# Altium schematic sheet [07] - 5V VOLTAGE REGULATOR.SchDoc("[07] - 5V VOLTAGE REGULATOR")
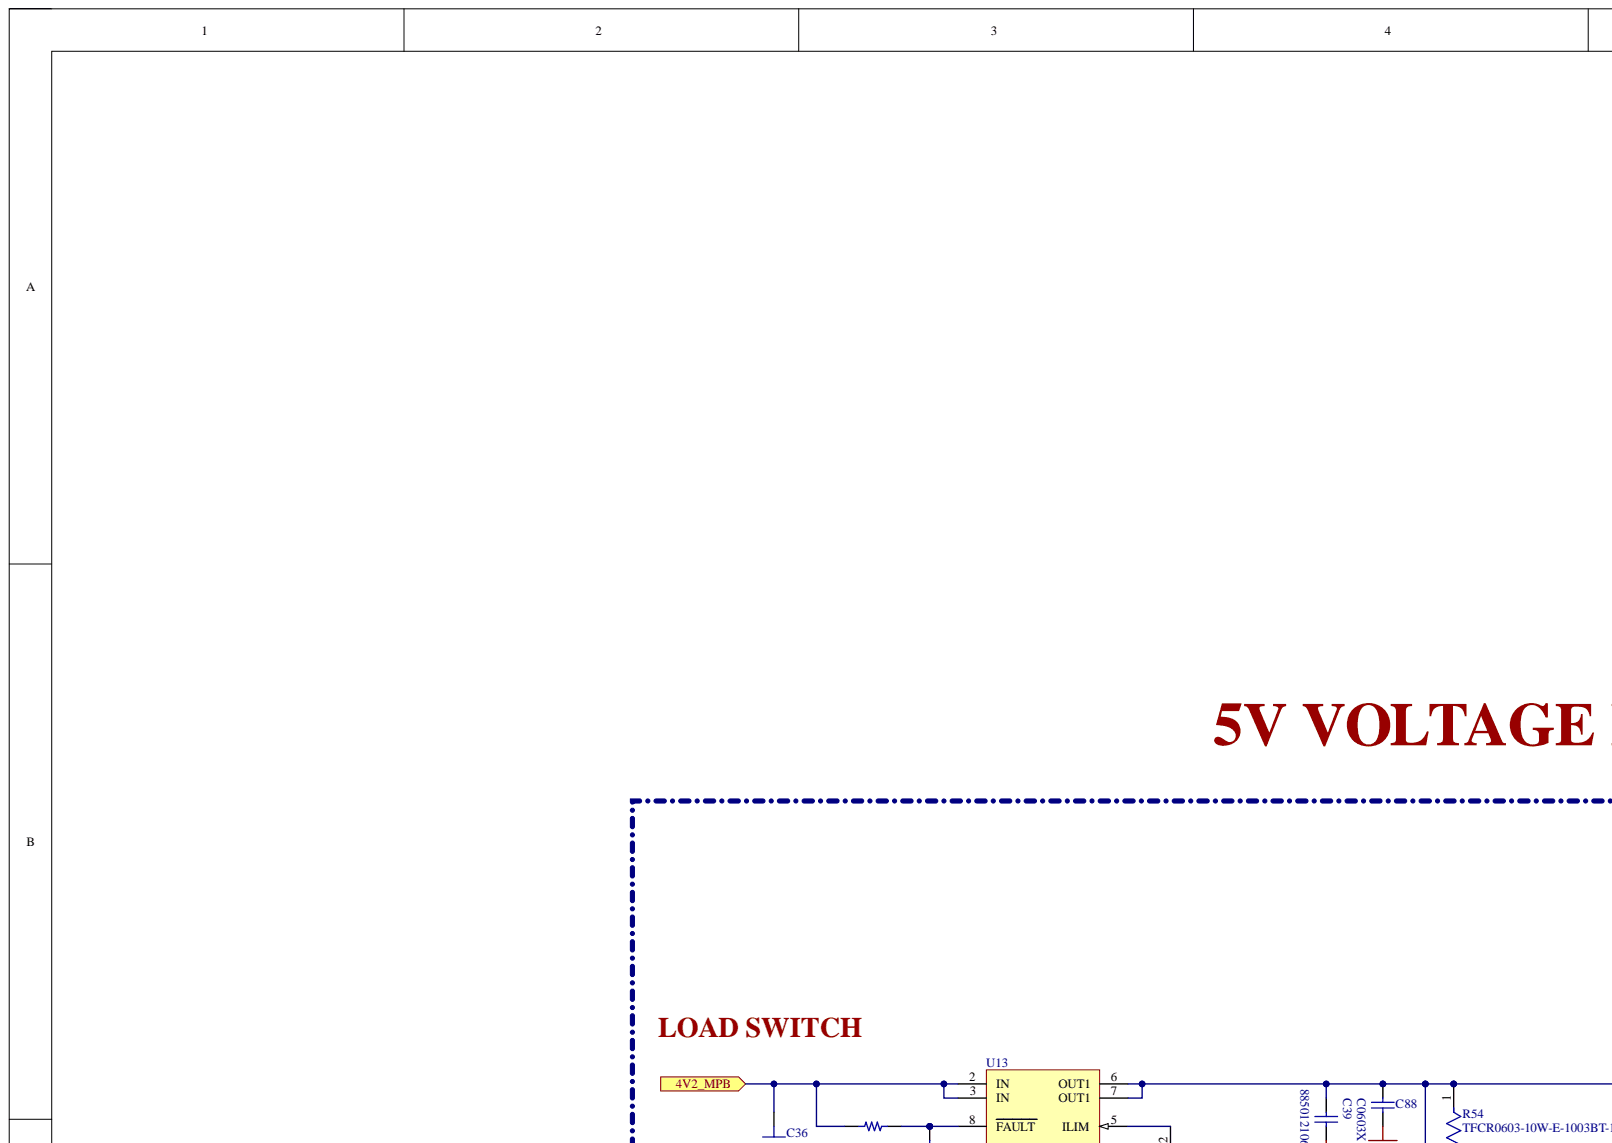
[diagram p0: [07] - 5V VOLTAGE REGULATOR.SchDoc, top left]
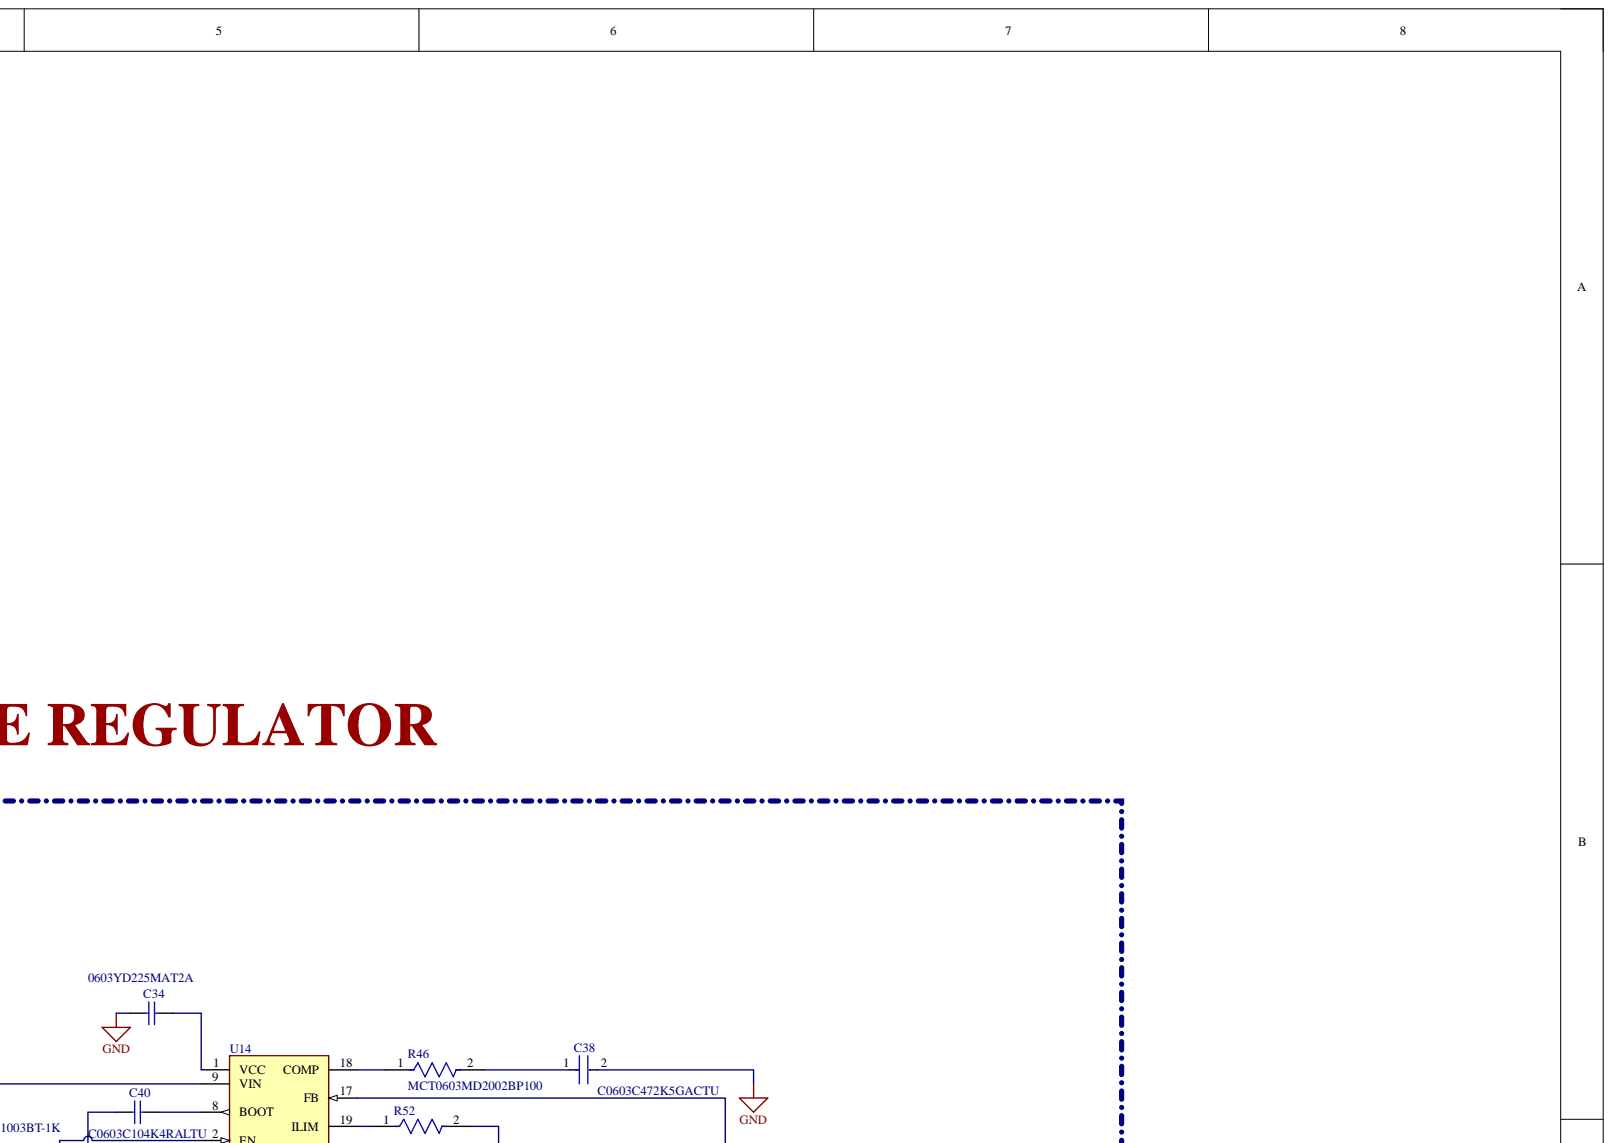
[diagram p1: [07] - 5V VOLTAGE REGULATOR.SchDoc, top right]
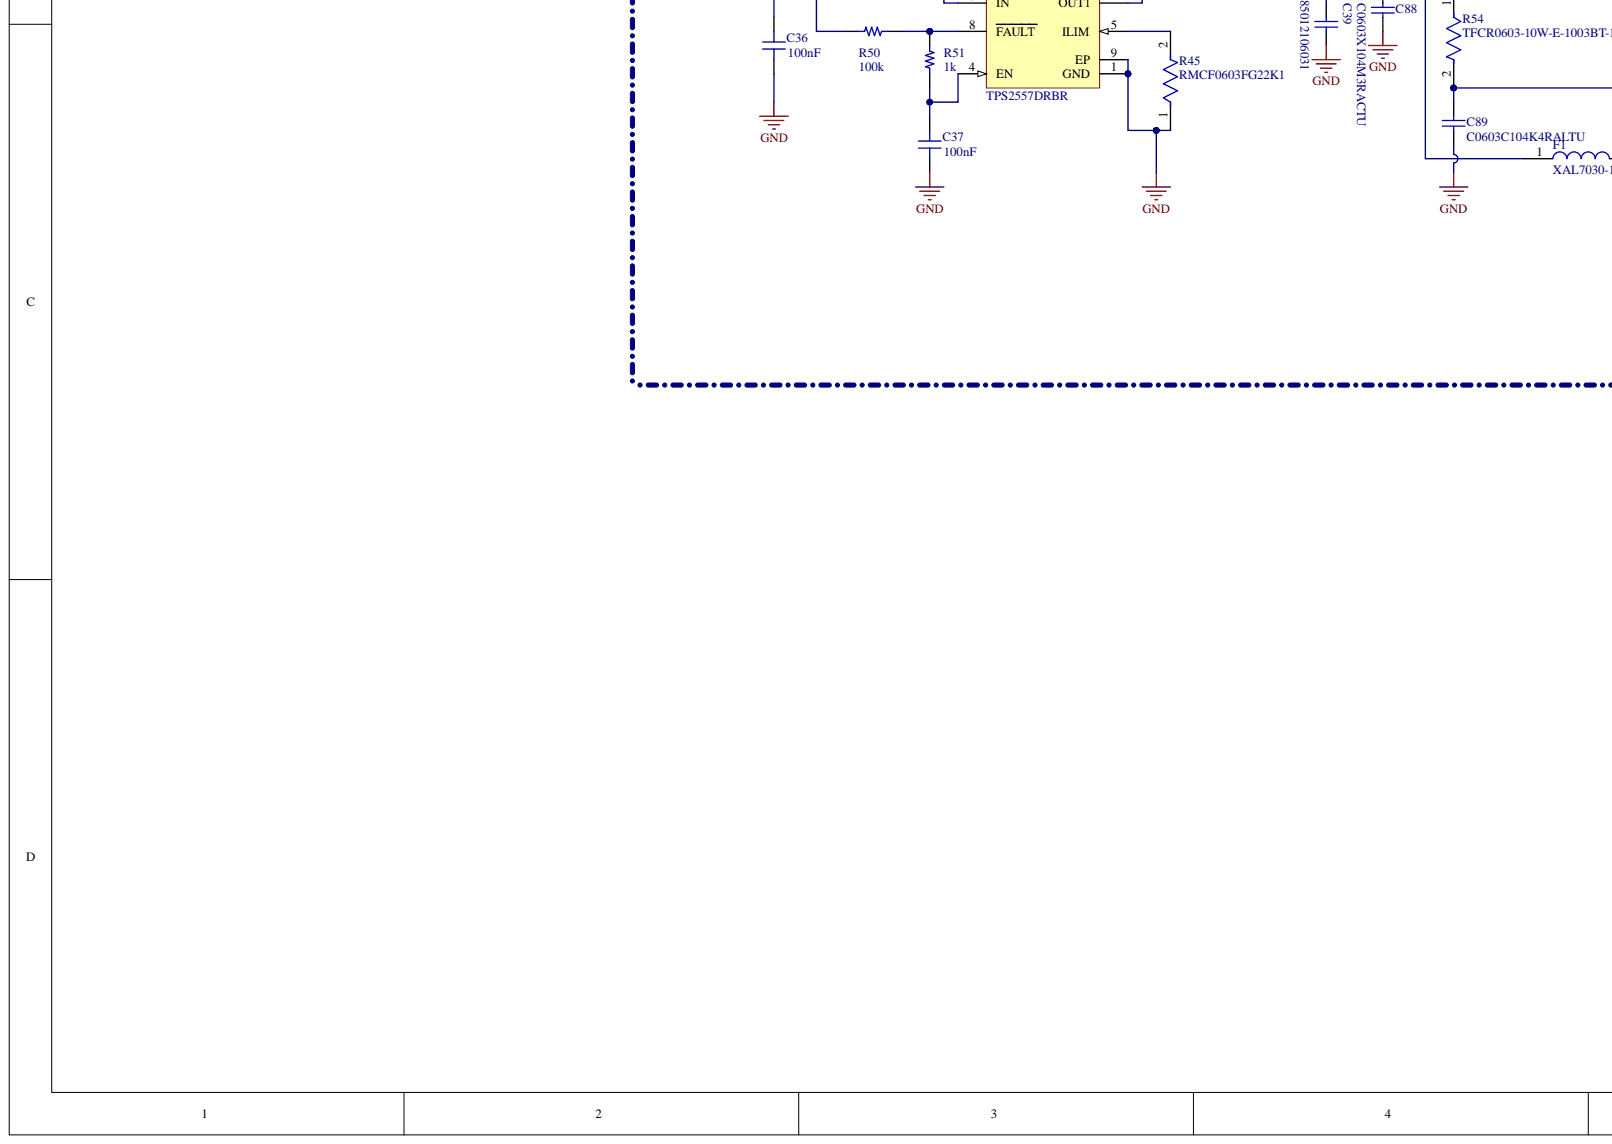
[diagram p2: [07] - 5V VOLTAGE REGULATOR.SchDoc, bottom left]
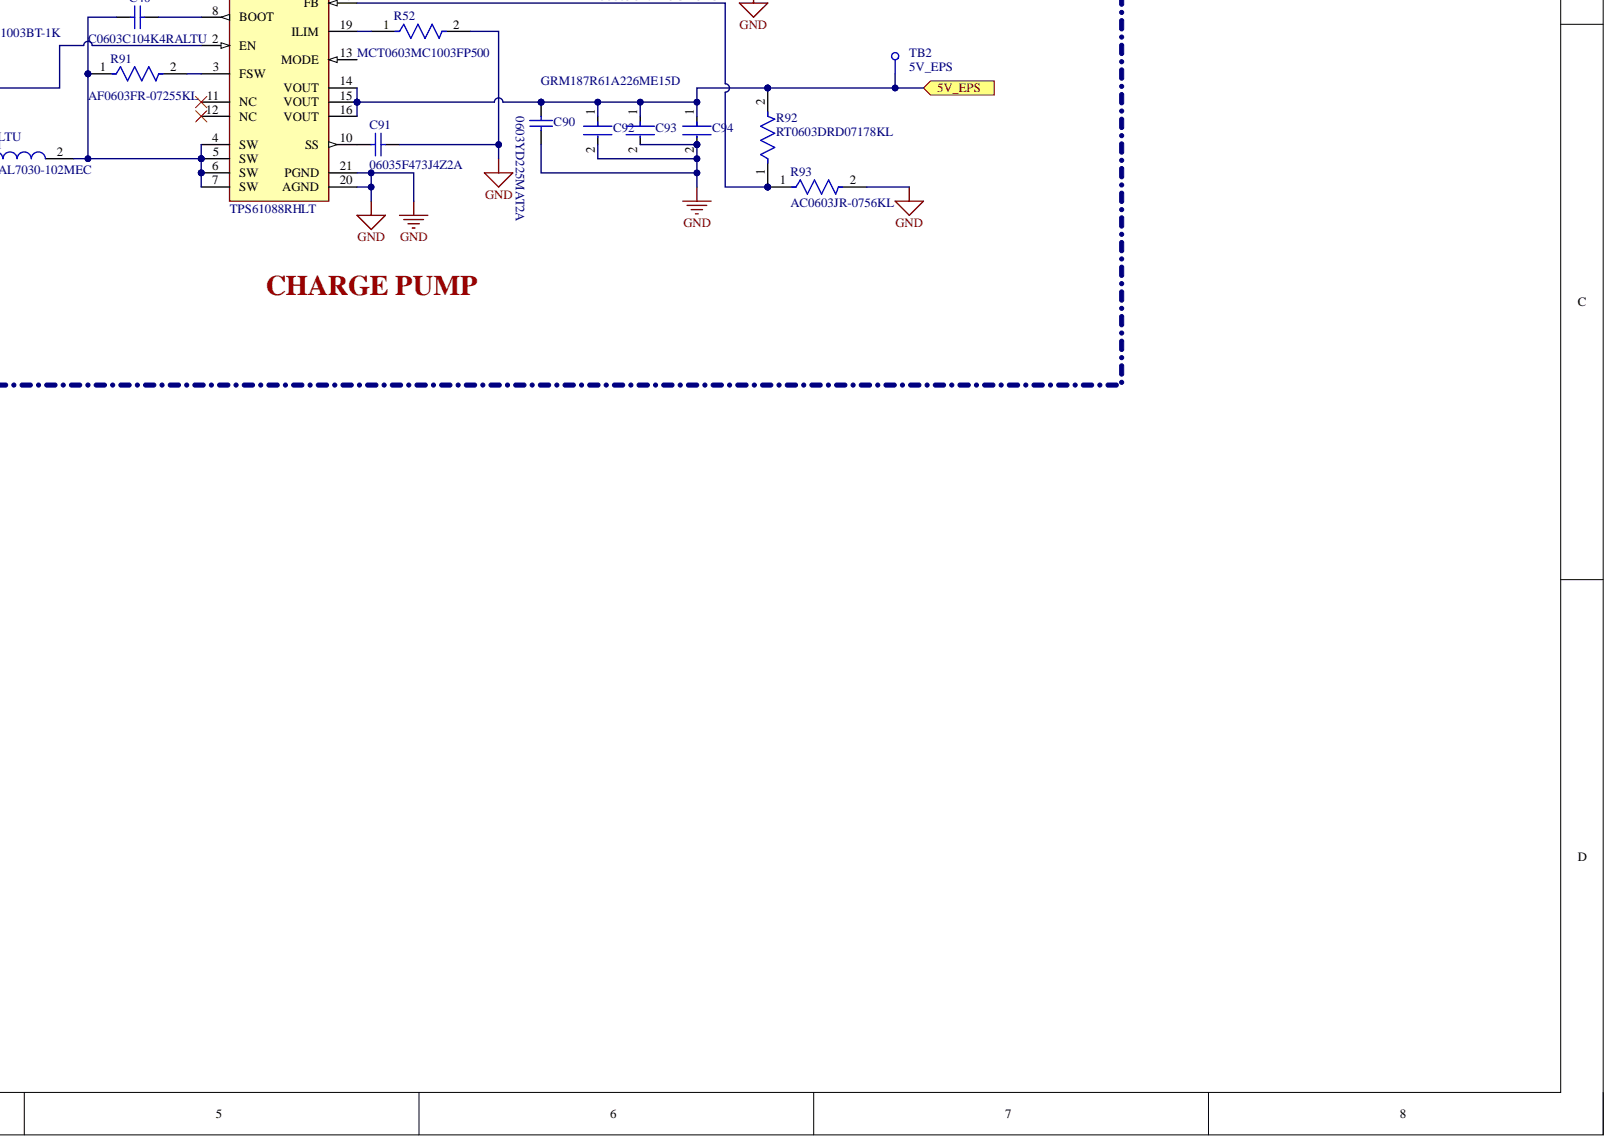
[diagram p3: [07] - 5V VOLTAGE REGULATOR.SchDoc, bottom right]

## Parts
C34  0603YD225MAT2A  [CAPC1608X90X35NL15T15]
C36  Capacitance: 100nF  [CAP 0603/1608]  (hidden comment: C0603C104K8RACTU)
C37  Capacitance: 100nF  [CAP 0603/1608]  (hidden comment: C0603C104K8RACTU)
C38  C0603C472K5GACTU  [FP-C0603C-CF-MFG]
C39  885012106031  [WCAP-CSGP_0603_L1.6W0.8H0.8_Reflow]
C40  C0603C104K4RALTU  [CAPC1608X87X35ML15T15]
C88  C0603X104M3RACTU  [CAPC1608X87X35LL15T15]
C89  C0603C104K4RALTU  [CAPC1608X87X35ML15T15]
C90  0603YD225MAT2A  [CAPC1608X90X35NL15T15]
C91  06035F473J4Z2A  [CAPC1608X90X35NL15T15]
C92  GRM187R61A226ME15D  [FP-GRM187-0_2-IPC_C]
C93  GRM187R61A226ME15D  [FP-GRM187-0_2-IPC_C]
C94  GRM187R61A226ME15D  [FP-GRM187-0_2-IPC_C]
F1  XAL7030-102MEC  [XAL7030102MEC]
R45  RMCF0603FG22K1  [FP-RMCF0603-MFG]
R46  MCT0603MD2002BP100  [FP-MCT0603-IPC_A]
R50  Resistance: 100k  [RES 0603/1608]  (hidden comment: CR0603-JW-104ELF)
R51  Resistance: 1k  [RES 0603/1608]  (hidden comment: ESR03EZPJ102)
R52  MCT0603MC1003FP500  [FP-MCT0603-IPC_C]
R54  TFCR0603-10W-E-1003BT-1K  [FP-TFCR0603-IPC_C]
R91  AF0603FR-07255KL  [FP-AF0603-IPC_B]
R92  RT0603DRD07178KL  [FP-RT0603-MFG]
R93  AC0603JR-0756KL  [FP-AC0603-MFG]
TB2  5V_EPS  [Testpoint]
U13  TPS2557DRBR  [DRB0008B_V]
U14  TPS61088RHLT  [FP-RHL0020A-MFG]

## Ports
4V2_MPB, 5V_EPS

## Nets
GND: C34.1 C36.1 C37.1 C38.2 C39.2 C88.1 C89.1 C90.1 C91.2 C92.2 C93.2 C94.2 R45.1 R52.2 R93.2 U13.1(GND) U13.9(EP) U14.20(AGND) U14.21(PGND)
4V2_MPB: C36.2 R50.1 U13.2(IN) U13.3(IN) ; port 4V2_MPB
5V_EPS: C90.2 C92.1 C93.1 C94.1 R92.2 TB2.1 U14.14(VOUT) U14.15(VOUT) U14.16(VOUT) ; port 5V_EPS
NetC34_2: C34.2 U14.1(VCC)
NetC37_2: C37.2 R51.1 U13.4(EN)
NetC38_1: C38.1 R46.2
NetC39_1: C39.1 C88.2 F1.1 R54.1 U13.6(OUT1) U13.7(OUT1) U14.9(VIN)
NetC40_1: C40.1 F1.2 R91.1 U14.4(SW) U14.5(SW) U14.6(SW) U14.7(SW)
NetC40_2: C40.2 U14.8(BOOT)
NetC89_2: C89.2 R54.2 U14.2(EN)
NetC91_1: C91.1 U14.10(SS)
NetR45_2: R45.2 U13.5(ILIM)
NetR46_1: R46.1 U14.18(COMP)
NetR50_2: R50.2 R51.2 U13.8(FAULT)
NetR52_1: R52.1 U14.19(ILIM)
NetR91_2: R91.2 U14.3(FSW)
NetR92_1: R92.1 R93.1 U14.17(FB)

Not connected: U14 11(NC) 12(NC) 13(MODE)

## Library data of the parts
C34 C90 = CMP-2006-04537-1: Manufacturer: AVX Interconnect / Elco; Component Kind: Standard; Library Name: Altium Content Vault; Part Number: 0603YD225MAT2A
C36 C37 = C0603C104K8RACTU: CAP CER 0.1UF 10V X7R 0603; Manufacturer: KEMET; Dielectric Material: Ceramic; Dissipation Factor: 5%; Tolerance: 10%; Case Code (Imperial): 0603; Case Code (Metric): 1608; Case/Package: 0603; Component Kind: Standard; ComponentLink1Description: http://www.farnell.com/datasheets/2315110.pdf?src-supplier=Farnell; ComponentLink1URL: http://www.farnell.com/datasheets/2315110.pdf?src-supplier=Farnell; ComponentLink2Description: http://www.kemet.com/kemet/web/homepage/kechome.nsf/vapubfiles/KEM_C1002_X7R_SMD.pdf/$file/KEM_C1002_X7R_SMD.pdf?src-supplier=Element14; ComponentLink2URL: http://www.kemet.com/kemet/web/homepage/kechome.nsf/vapubfiles/KEM_C1002_X7R_SMD.pdf/$file/KEM_C1002_X7R_SMD.pdf?src-supplier=Element14; ComponentLink3Description: https://www.verical.com/server-webapp/html/getDatasheet?partID=91529&src-supplier=Verical; ComponentLink3URL: https://www.verical.com/server-webapp/html/getDatasheet?partID=91529&src-supplier=Verical; ComponentLink4Description: http://www.mouser.com/ds/2/212/KEM_C1002_X7R_SMD-1102033.pdf?src-supplier=Mouser; ComponentLink4URL: http://www.mouser.com/ds/2/212/KEM_C1002_X7R_SMD-1102033.pdf?src-supplier=Mouser; ComponentLink5Description: http://www.alliedelec.com/m/d/9bfe7773041ffe9d406e68bc7b377fdd.pdf?src-supplier=Allied+Electronics; ComponentLink5URL: http://www.alliedelec.com/m/d/9bfe7773041ffe9d406e68bc7b377fdd.pdf?src-supplier=Allied+Electronics; ComponentLink6Description: http://www.farnell.com/datasheets/1851736.pdf?src-supplier=Element14; ComponentLink6URL: http://www.farnell.com/datasheets/1851736.pdf?src-supplier=Element14; Contact Plating: Tin,Matte; Depth: 0.8mm; Dielectric: X7R; Height: 0.8mm; Lead Pitch: 0.7mm; Length: 1.6mm; Manufacturer Part Number: C0603C104K8RACTU; Max Operating Temperature: 125°C; Min Operating Temperature: -55°C; Mount: Surface Mount,PCB; Number of Pins: 2; Package Quantity: 1; Packaging: Tape and Reel; Pricing 1: 1=0.15, 10=0.107, 100=0.0504, 500=0.03536, 1000=0.02927 (USD); Radiation Hardening: No; REACH SVHC: No SVHC; Stock 1: 778651; Supplier 1: Digi-Key; Supplier Part Number 1: 399-1095-1-ND; Termination: SMD/SMT; Thickness: 0.034inch; Voltage: 10V; Voltage Rating: 10V; Voltage Rating (DC): 10V; Width: 0.8mm; Library Name: UVG.IntLib
C38 = CMP-2006-03600-2: CAP CER 4700PF 50V 10% NP0 0603; Manufacturer: KEMET; Automotive Grade: Grade 1; Capacitance: 4,700 pF; Material: Ceramic; Temperature Characteristic: C0G; Temperature Coefficient: 30ppm/°C; Tolerance: 0.1; Value: 4,700 pF; Automotive: Yes; Category: Cap; Component Kind: Standard; ComponentLink2Description: Datasheet; ComponentLink2URL: https://api.kemet.com/component-edge/download/datasheet/C0603C100J5GACAUTO.pdf; Device Class L1: Passive Components; Device Class L2: Capacitors; Device Class L3: Ceramic Capacitors; Digikey Description: CAP CER 4700PF 50V 10% NP0 0603; Height: 0.87mm; Lead Free: Yes; Library Name: Altium Content Vault; Manufacturer Part Number: C0603C472K5GACTU; Mouser Description: Cap Ceramic 0.0047uF 50V C0G 10% SMD 0603 125°C Paper T/R; Octopart: https://octopart.com/c0603c472k5gactu-kemet-19050079; Package: C0603C-CF; RoHS: TRUE; Temperature: -55°C to +125°C; Temperature Range High: +125°C; Temperature Range Low: -55°C; Voltage Rating: 50 V
C39 = CMP-207839-000060-3: Cap, 10Uf, 25V, Mlcc, 0603 Rohs Compliant: Yes; Capacitance: 10µF; Insulation Resistance: 10MΩ; Component Kind: Standard; Height: 800µm; Length: 1.6mm; Library Name: Altium Content Vault; Max Operating Temperature: 85°C; Min Operating Temperature: -55°C; RoHS: Compliant; Voltage Rating: 25V; Width: 800µm
C40 C89 = CMP-2006-03059-1: Manufacturer: Kemet; Component Kind: Standard; Library Name: Altium Content Vault; Part Number: C0603C104K4RALTU
C88 = CMP-2006-03301-1: Manufacturer: Kemet; Component Kind: Standard; Library Name: Altium Content Vault; Part Number: C0603X104M3RACTU
C91 = CMP-2006-02143-1: Manufacturer: AVX Interconnect / Elco; Component Kind: Standard; Library Name: Altium Content Vault; Part Number: 06035F473J4Z2A
C92-C94 = CMP-06035-049226-1: Chip Multilayer Ceramic Capacitors for General Purpose, 0603, 22uF, X5R, 15%, 20%, 10V; Capacitance: 22uF; Case Code (Imperial): 0603; Case Code (Metric): 1608; Case/Package: 0603; Component Kind: Standard; Dielectric: X5R; Length: 0.063inch; Library Name: Altium Content Vault; Max Operating Temperature: 85°C; Min Operating Temperature: -55°C; Mount: Surface Mount; Packaging: Tape and Reel; Thickness: 0.031inch; Tolerance: 20%; Voltage Rating: 10V; Voltage Rating (DC): 10V; Width: 0.031inch
F1 = XAL7030-102MEC: Inductor; Manufacturer_Name: COILCRAFT; Component Kind: Standard; Datasheet Link: https://www.coilcraft.com/pdfs/xal7030.pdf; Height: 3.1mm; Manufacturer_Part_Number: XAL7030-102MEC; Mouser Part Number: 994-XAL7030-102MEC; Mouser Price/Stock: https://www.mouser.co.uk/ProductDetail/Coilcraft/XAL7030-102MEC?qs=zCSbvcPd3pYbk2HvDIgkpA%3D%3D
R45 = CMP-26527-003325-1: 22.1kΩ ±1% 0.1W 0603 Thick Film Chip Resistor AEC-Q200 compliant; Max Power Dissipation: 100mW; Resistance: 22.1kR; Temperature Coefficient: 100ppm/°C; Case/Package: 0603; Component Kind: Standard; Contact Plating: Tin; Features: Automotive AEC-Q200; Height: 0.022inch; Length: 0.061inch; Library Name: Altium Content Vault; Max Operating Temperature: 155°C; Min Operating Temperature: -55°C; Mount: Surface Mount; Number of Terminations: 2; Packaging: Tape and Reel; Radiation Hardening: No; Resistor Type: Thick Film; RoHS Compliant: Yes; Series: RMCF; Tolerance: 1%; Width: 0.031inch
R46 = CMP-02407-011165-1: RES SMD 100 OHM 1% 1/8W 0603; Max Power Dissipation: 125mW; Resistance: 20kR; Temperature Coefficient: 25ppm/°C; Tolerance: 0.1%; Voltage Rating: 75V; Case Code (Imperial): 0603; Case Code (Metric): 1608; Case/Package: 0603; Component Kind: Standard; Construction: Rectangular; Depth: 0.85mm; Features: Automotive AEC-Q200; Height: 0.022inch; Length: 1.55mm; Library Name: Altium Content Vault; Max Operating Temperature: 155°C; Military Standard: Not; Min Operating Temperature: -55°C; Number of Terminations: 2; Package Quantity: 1000; Packaging: Tape and Reel; Power Rating: 125mW; Resistor Type: Thin Film; Series: MCT 0603 - Precision; Termination: SMD/SMT; Weight: 6.7E-05oz; Width: 0.033inch
R50 = CR0603-JW-104ELF: RES SMD 100K OHM 5% 1/10W 0603; Manufacturer: Bourns; Power Rating: 100mW; Temperature Coefficient: 200ppm/°C; Voltage Rating: 50V; Case Code (Imperial): 0603; Case Code (Metric): 1608; Case/Package: 0603; Component Kind: Standard; ComponentLink1Description: https://datasheet.ciiva.com/22713/691949-22713239.pdf?src-supplier=Element14; ComponentLink1URL: https://datasheet.ciiva.com/22713/691949-22713239.pdf?src-supplier=Element14; ComponentLink2Description: https://datasheet.ciiva.com/23776/1902866-23776391.pdf?src-supplier=Newark; ComponentLink2URL: https://datasheet.ciiva.com/23776/1902866-23776391.pdf?src-supplier=Newark; ComponentLink3Description: https://datasheet.ciiva.com/16766/70154685-16766760.pdf?src-supplier=Allied+Electronics; ComponentLink3URL: https://datasheet.ciiva.com/16766/70154685-16766760.pdf?src-supplier=Allied+Electronics; ComponentLink4Description: https://datasheet.ciiva.com/30229/159chpreztr-30229129.pdf?src-supplier=Onlinecomponents.com; ComponentLink4URL: https://datasheet.ciiva.com/30229/159chpreztr-30229129.pdf?src-supplier=Onlinecomponents.com; ComponentLink5Description: https://datasheet.ciiva.com/16935/chpreztr-16935963.pdf?src-supplier=Avnet+Europe; ComponentLink5URL: https://datasheet.ciiva.com/16935/chpreztr-16935963.pdf?src-supplier=Avnet+Europe; ComponentLink6Description: https://datasheet.ciiva.com/5543/chpreztr-5543676.pdf?src-supplier=Mouser; ComponentLink6URL: https://datasheet.ciiva.com/5543/chpreztr-5543676.pdf?src-supplier=Mouser; ComponentLink7Description: https://datasheet.ciiva.com/9120/chpreztr-9120764.pdf?src-supplier=Avnet; ComponentLink7URL: https://datasheet.ciiva.com/9120/chpreztr-9120764.pdf?src-supplier=Avnet; ComponentLink8Description: https://datasheet.ciiva.com/46383/1791942-46383790.pdf?src-supplier=Element14; ComponentLink8URL: https://datasheet.ciiva.com/46383/1791942-46383790.pdf?src-supplier=Element14; ComponentLink9Description: https://datasheet.ciiva.com/48692/001055501-da-01-en-smd-wid-cr0603-jw-104elf-100k-ohm-1-10w-48692133.pdf?src-supplier=Conrad; ComponentLink9URL: https://datasheet.ciiva.com/48692/001055501-da-01-en-smd-wid-cr0603-jw-104elf-100k-ohm-1-10w-48692133.pdf?src-supplier=Conrad; Composition: Thick Film; Contact Plating: Tin; Depth: 0.8mm; Height: 0.45mm; Length: 1.6mm; Manufacturer Part Number: CR0603-JW-104ELF; Max Operating Temperature: 155°C; Military Standard: Not; Min Operating Temperature: -55°C; Mount: Surface Mount; Number of Pins: 2; Package Quantity: 5000; Packaging: Tape and Reel; Pricing 1: 1 = 0.10000, 10 = 0.01500, 25 = 0.01080, 50 = 0.00840, 100 = 0.00610, 250 = 0.00468, 500 = 0.00374, 1000 = 0.00275 (USD); Radiation Hardening: No; REACH SVHC: No SVHC; RoHS: Yes; Stock 1: 138181; Supplier 1: Digi-Key; Supplier Part Number 1: CR0603-JW-104ELFCT-ND; Termination: SMD/SMT; Thickness: 457um; Tolerance: 5%; Voltage Rating (DC): 50V; Width: 0.8mm; Working Voltage: 50V; Library Name: UVG.IntLib
R51 = ESR03EZPJ102: RES SMD 1K OHM 5% 1/4W 0603; Manufacturer: Rohm; Max Power Dissipation: 250mW; Temperature Coefficient: 200ppm/°C; Case Code (Imperial): 0603; Case Code (Metric): 1608; Case/Package: 0603; Component Kind: Standard; ComponentLink1Description: https://datasheet.ciiva.com/2469/esr-2469603.pdf?src-supplier=Digi-Key; ComponentLink1URL: https://datasheet.ciiva.com/2469/esr-2469603.pdf?src-supplier=Digi-Key; ComponentLink2Description: https://datasheet.ciiva.com/2469/esr-2469604.pdf?src-supplier=Digi-Key; ComponentLink2URL: https://datasheet.ciiva.com/2469/esr-2469604.pdf?src-supplier=Digi-Key; ComponentLink3Description: https://datasheet.ciiva.com/2469/esr-2469605.pdf?src-supplier=Digi-Key; ComponentLink3URL: https://datasheet.ciiva.com/2469/esr-2469605.pdf?src-supplier=Digi-Key; Composition: Thick Film; Features: Automotive AEC-Q200; Height: 0.022inch; Lead Free: Lead Free; Length: 0.063inch; Manufacturer Part Number: ESR03EZPJ102; Max Operating Temperature: 155°C; Min Operating Temperature: -55°C; Number of Terminations: 2; Package Quantity: 1; Packaging: Tape and Reel; Pricing 1: 1 = 0.10000, 10 = 0.08300, 100 = 0.03180, 1000 = 0.01398, 2500 = 0.01207 (USD); RoHS: Yes; Stock 1: 187581; Supplier 1: Digi-Key; Supplier Part Number 1: RHM1.0KDCT-ND; Tolerance: 5%; Width: 0.031inch; Library Name: UVG.IntLib
R52 = CMP-02407-008119-1: RES SMD 100 OHM 1% 1/8W 0603; Power Rating: 125mW; Resistance: 100kR; Voltage Rating: 75V; Case Code (Imperial): 0603; Case Code (Metric): 1608; Case/Package: 0603; Component Kind: Standard; Composition: Thin Film; Height: 0.45mm; Length: 1.55mm; Library Name: Altium Content Vault; Max Operating Temperature: 175°C; Min Operating Temperature: -55°C; Packaging: Tape and Reel; Tolerance: 1%; Weight: 6.7E-05oz; Width: 0.85mm
R54 = CMP-250906-266161-1: RES Thin Film,  100kΩ, 0.1%, 0.1W, 25ppm/°C, 0603; Component Kind: Standard; Library Name: Altium Content Vault
R91 = CMP-03412-040990-1: Anti-Sulfurated Chip Resistors Automotive Grade 255kΩ 1% 100ppm/°C 0603; Max Power Dissipation: 100mW; Resistance: 255kR; Case/Package: 0603; Component Kind: Standard; Features: Moisture Resistant; Height: 0.022inch; Length: 0.063inch; Library Name: Altium Content Vault; Max Operating Temperature: 155°C; Min Operating Temperature: -55°C; Number of Terminations: 2; Packaging: Tape and Reel; Resistor Type: Thick Film; RoHS Compliant: Yes; Series: AF; Temperature Coefficient: 100ppm/°C; Tolerance: 1%; Width: 0.031inch
R92 = CMP-03412-010615-1: RES SMD 178K OHM 0.5% 1/10W 0603; Manufacturer: Yageo; Power Rating: 0.1W; Resistance: 178KΩ; Temperature Coefficient: 25 ppm/°C; Tolerance: 0.005; Value: 178KΩ; Voltage Rating: 75V; Automotive: No; Category: Res; Component Kind: Standard; ComponentLink2Description: Datasheet; ComponentLink2URL: http://www.yageo.com/documents/recent/PYu-RT_1-to-0.01_RoHS_L_9.pdf; Composition: Thin Film; Device Class L1: Passive Components; Device Class L2: Resistors; Device Class L3: Chip SMD Resistors; Digikey Description: RES SMD 178K OHM 0.5% 1/10W 0603; Footprint Url: http://www.yageo.com/exep/pages/download/literatures/PYu-R_Mount_10.pdf; Height: 0.55mm; Ipc Land Pattern Name: RESC16080X45; Lead Free: Yes; Library Name: Altium Content Vault; Manufacturer Part Number: RT0603DRD07178KL; Octopart: https://octopart.com/rt0603drd07178kl-yageo-1271844; Package: RT0603; RoHS: TRUE; Series: RT; Temperature: -55°C to +155°C; Temperature Range High: +155°C; Temperature Range Low: -55°C
R93 = CMP-03412-041791-1: RES 56kΩ 5.00% 0.1W 0603; Max Power Dissipation: 100mW; Resistance: 56kR; Temperature Coefficient: 100ppm/°C; Tolerance: 5%; Voltage Rating: 50V; Case Code (Imperial): 0603; Case Code (Metric): 1608; Case/Package: 0603; Component Kind: Standard; Features: Moisture Resistant; Height: 0.022inch; Length: 0.063inch; Library Name: Altium Content Vault; Max Operating Temperature: 155°C; Min Operating Temperature: -55°C; Number of Terminations: 2; Packaging: Tape and Reel; Power Rating: 100mW; Resistor Type: Thick Film; RoHS Compliant: Yes; Series: AC; Termination: SMD/SMT; Width: 0.8mm
TB2 = Testpoint: GENERIC TESTPOINT; Component Kind: Standard; Library Name: UVG.IntLib
U13 = CMP-0337-00220-2: Precision Adjustable Current-Limited Power-Distribution Switch, 0.5 to 5.0 A Current Limit, -40 to 85 degC, 8-pin SON (DRB), Green (RoHS & no Sb/Br); Manufacturer: Texas Instruments; Continuous Current(Max)(A): 5; Current Limit Accuracy @ 1A: +/-7.0% (4100mA min / 4715mA max); Current Limit Topology: Constant-Current; Enable: Active High; Number of Switches: 1; Rating: Catalog; rDS(on) per FET(Typ)(mOhms): 22; Vin(Max)(V): 6.5; Vin(Min)(V): 2.5; Component Kind: Standard; ComponentLink1Description: Datasheet; ComponentLink1URL: http://www.ti.com/general/docs/lit/getliterature.tsp?genericPartNumber=TPS2557&fileType=pdf; Current Limit(A): 0.5 to 5.0; DatasheetVersion: SLVS931A; Eco-Plan: Green (RoHS & no Sb/Br); Library Name: Altium Content Vault; Mounting Technology: Surface Mount; PackageDescription: 8-Pin Plastic Small Outline No-Lead, 3 x 3 x 1 mm Body, 0.65 mm Pitch, Exposed Pad; PackageReference: DRB0008B; PackageVersion: revK, Jun-2012; PartNumber: TPS2557DRBR
U14 = CMP-04918-000117-1: IC REG BOOST ADJ 10A 20VQFN; Manufacturer: Texas Instruments; Max Duty Cycle: 90%; Max Junction Temp: +125°C; Max Supply Voltage: 12V; Min Supply Voltage: 2.7V; Nominal Supply Current: 1-110uA; Number Of Outputs: 1; Output Type: AdjustableProgrammable; Output Voltage: 4.5-12.6V; Switching Frequency: 200-2200kHz; Automotive: No; Category: IC; Component Kind: Standard; ComponentLink2Description: Datasheet; ComponentLink2URL: http://www.ti.com/lit/ds/symlink/tps61088.pdf; Device Class L1: Integrated Circuits (ICs); Device Class L2: Power Management ICs; Device Class L3: Voltage Regulators - Switching; Digikey Description: IC REG BOOST ADJ 10A 20VQFN; Height: 1mm; Lead Free: Yes; Library Name: Altium Content Vault; Manufacturer Part Number: TPS61088RHLT; Octopart: https://octopart.com/tps61088rhlt-texas+instruments-57155059; Output Current: 10A; Package: RHL0020A; RoHS: TRUE; Switching Topology: Boost; Temperature: -40°C to +85°C; Temperature Range High: +85°C; Temperature Range Low: -40°C
